# Altium schematic sheet BLDC_Motor_Reverser.SchDoc(BLDC_Motor_Reverser)
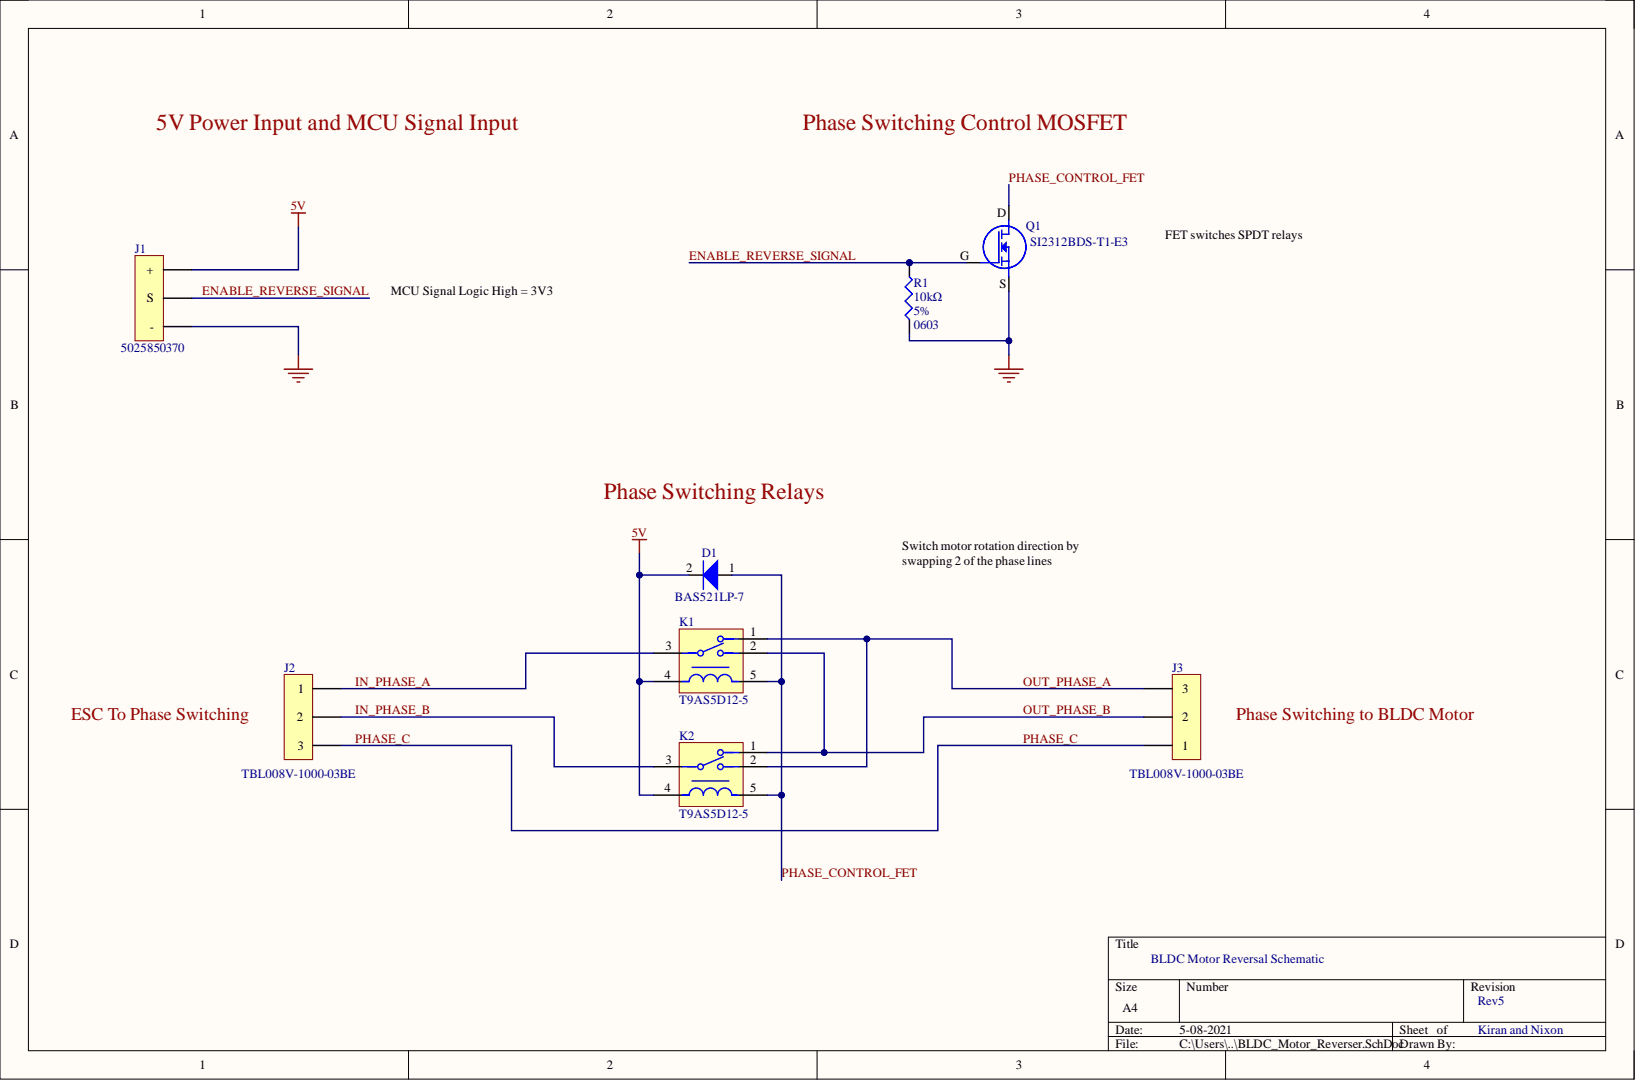
[diagram p0: BLDC_Motor_Reverser.SchDoc]

## Parts
D1  BAS521LP-7
J1  5025850370
J2  TBL008V-1000-03BE
J3  TBL008V-1000-03BE
K1  T9AS5D12-5  [FP-T9AS5D12-5-MFG]
K2  T9AS5D12-5  [FP-T9AS5D12-5-MFG]
Q1  SI2312BDS-T1-E3
R1  10kΩ  Package: 0603  Tolerance: 5%

## Nets
5V: D1.2 J1.1 K1.4 K2.4
GND: J1.3 Q1.S R1.1
ENABLE_REVERSE_SIGNAL: J1.2 Q1.G R1.2
IN_PHASE_A: J2.1 K1.3
IN_PHASE_B: J2.2 K2.3
OUT_PHASE_A: J3.3 K1.1 K2.2
OUT_PHASE_B: J3.2 K1.2 K2.1
PHASE_C: J2.3 J3.1
PHASE_CONTROL_FET: D1.1 K1.5 K2.5 Q1.D

## Library data of the parts
D1 = BAS521LP-7: Flyback Diode for SPDT; IFSM: 8.0A; VRRM: 325V; Component Kind: Standard; Library Name: BLDC_Motor_Lib.SchLib
J1 = 5025850370: Header Pins for power and microcontroller signal; Component Kind: Standard; Library Name: BLDC_Motor_Lib.SchLib
J2 J3 = TBL008V-1000-03BE: Terminal Block for 3 Phase lines; Component Kind: Standard; Library Name: BLDC_Motor_Lib.SchLib
K1 K2 = T9AS5D12-5: RELAY GEN PURPOSE SPDT 20A 5V; Coil Current: 200mA; Coil Power: 1W; Coil Resistance: 25R; Coil Type: Non-Latching; Contact Voltage Rating (AC): 277V; Current Rating: 30A; Max Voltage Rating (DC): 28V; Operate Time: 15ms; Relay Type: General Purpose; Coil Voltage (DC): 5V; Component Kind: Standard; Contact Current Rating: 20A; Contact Material: Silver; Height: 20.4mm; Length: 32.5mm; Library Name: BLDC_Motor_Lib.SchLib; Max Operating Temperature: 85°C; Max Voltage Rating (AC): 277V; Min Operating Temperature: -55°C; Mount: Through Hole; Number of Pins: 5; Package Quantity: 50; Packaging: Bulk; Release Time: 15ms; RoHS Compliant: Yes; Series: T9A; Termination: PCB; Throw Configuration: SPDT; Voltage Rating (DC): 5V; Weight: 0.918oz; Width: 27.4mm
R1 = CR0201AJW-103GLF: 10KOhm Resistor (Pulldown Res); Component Kind: Standard; Library Name: BLDC_Motor_Lib.SchLib
Q1 = SI2312BDS-T1-E3: Component Kind: Standard; Library Name: BLDC_Motor_Lib.SchLib
